# Altium schematic sheet 04_Misc.SchDoc(04_Misc)
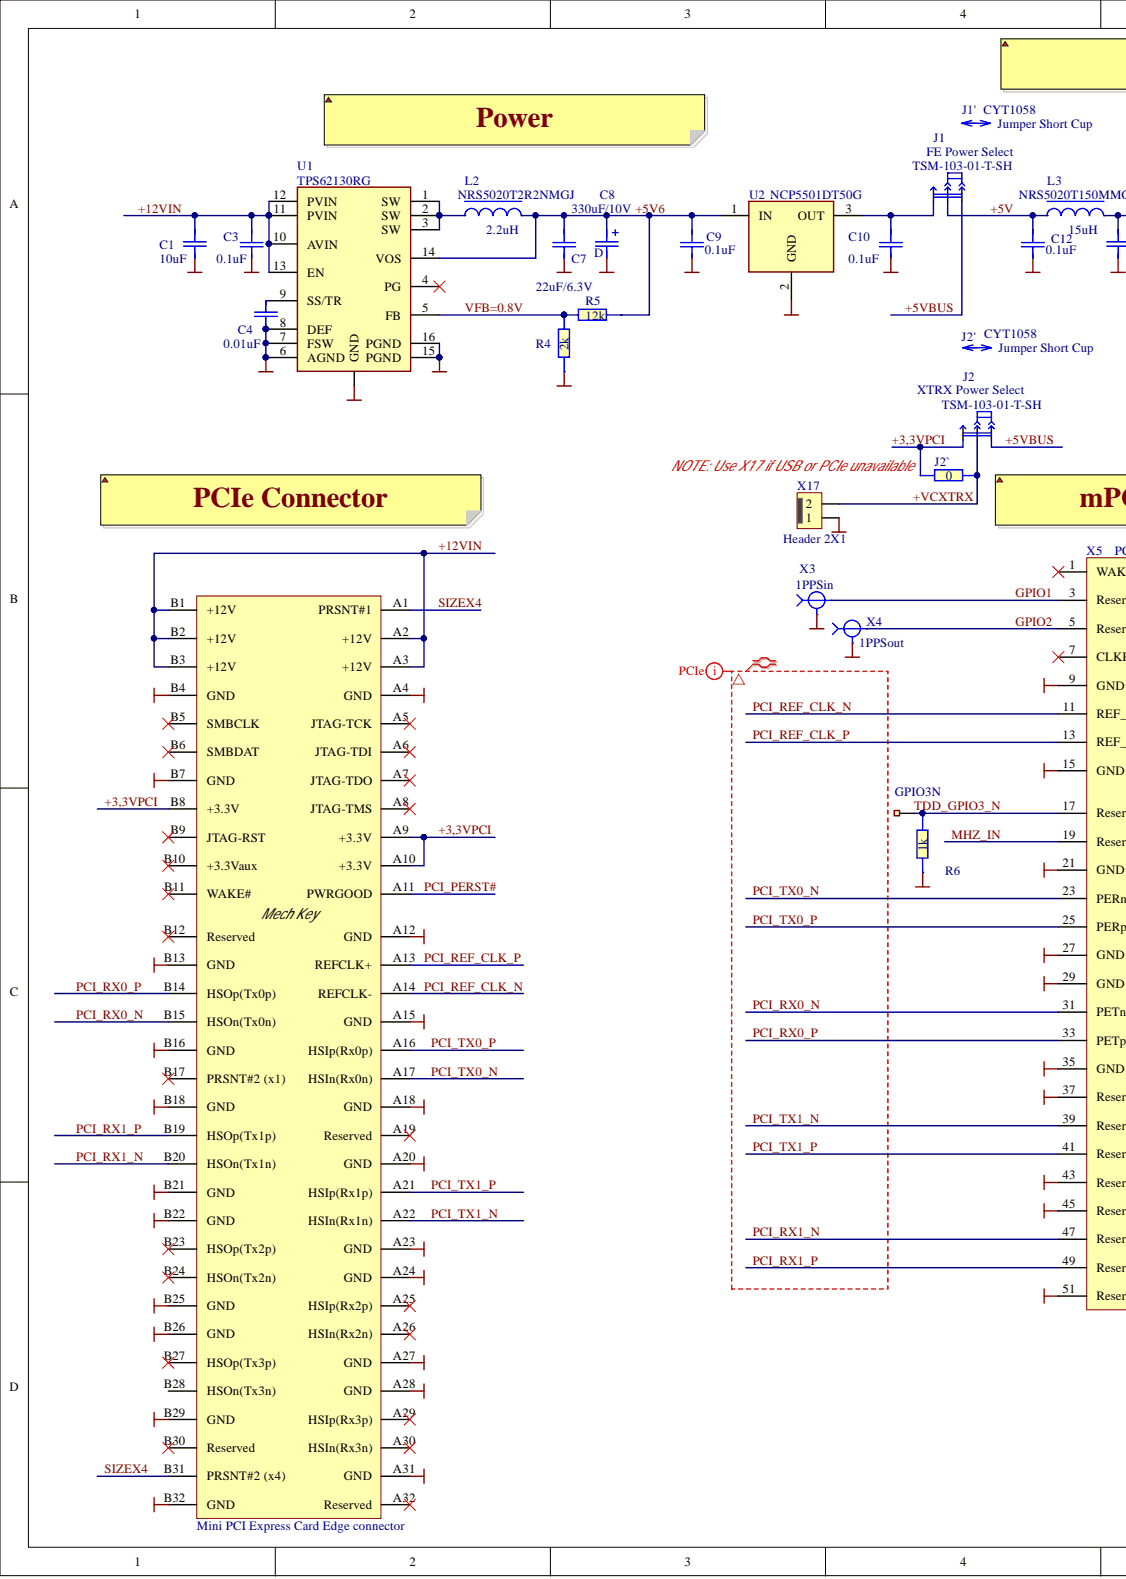
[diagram p0: 04_Misc.SchDoc, left]
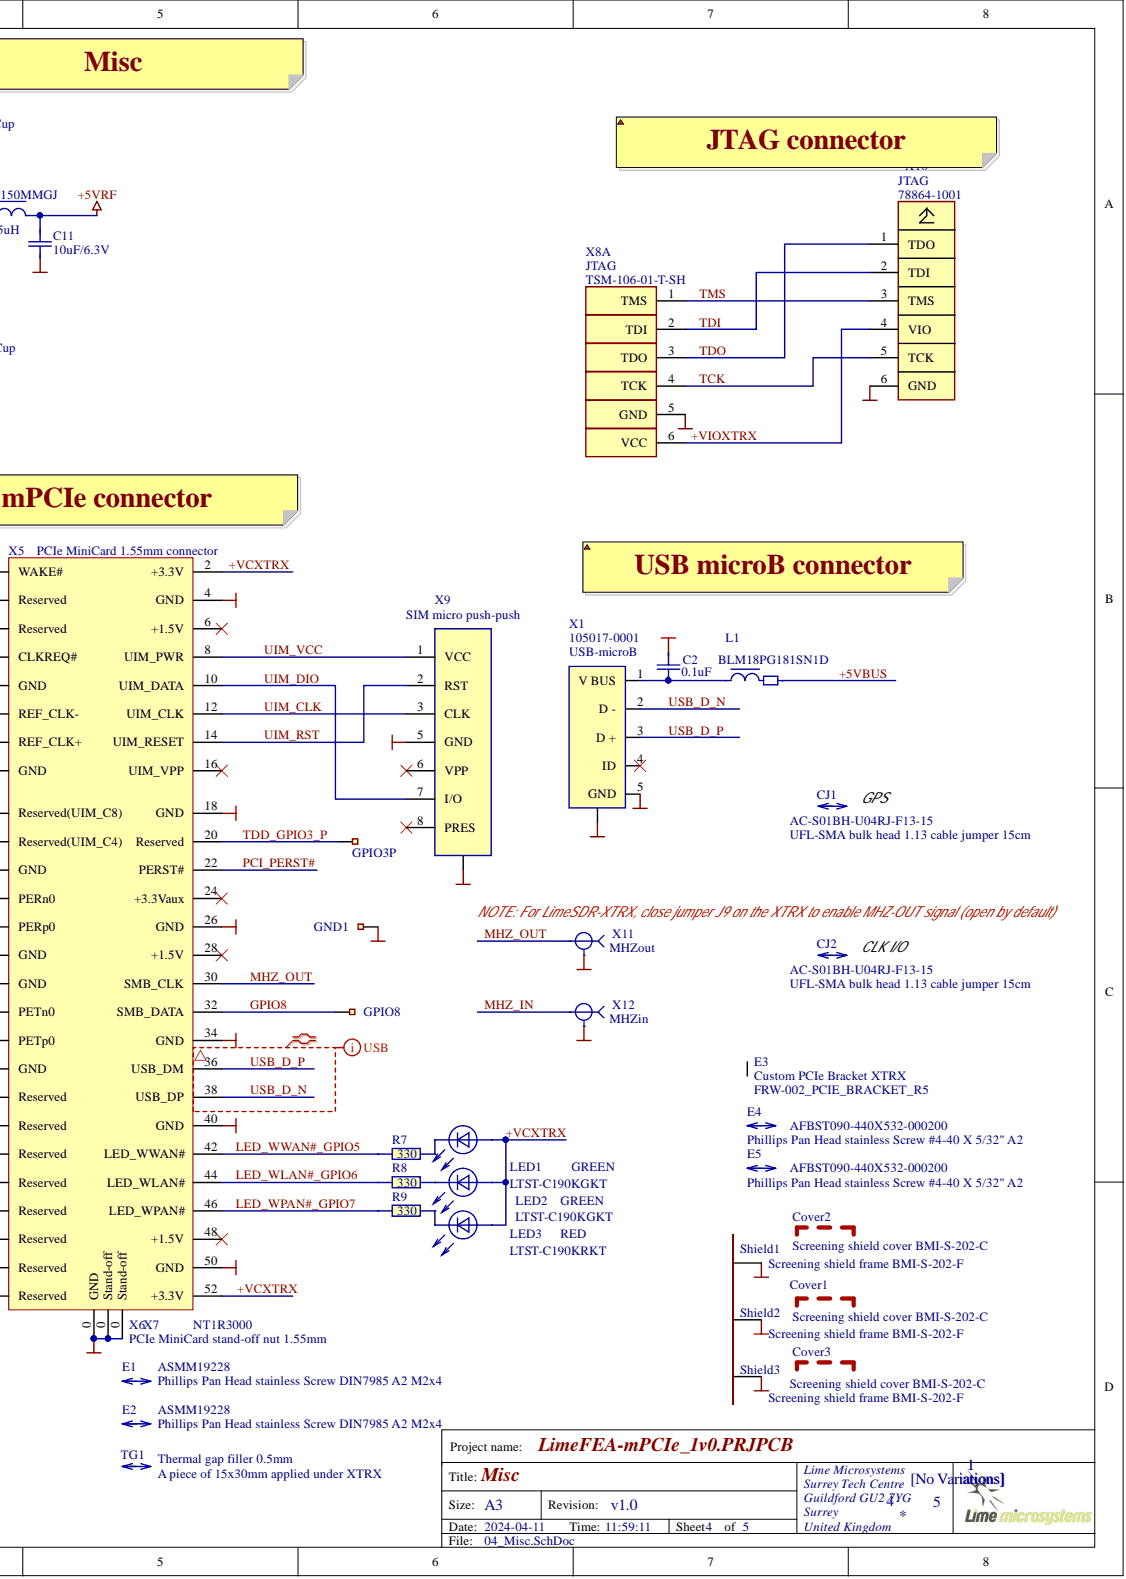
[diagram p1: 04_Misc.SchDoc, right]

## Parts
C1  10uF  [C0805]
C2  0.1uF  [C0603-PHILLIPS]
C3  0.1uF  [C0603-PHILLIPS]
C4  0.01uF  [C0603-PHILLIPS]
C7  22uF/6.3V  [C0603-PHILLIPS]
C8  330uF/10V  [cd7343]
C9  0.1uF  [C0603-PHILLIPS]
C10  0.1uF  [C0603-PHILLIPS]
C11  10uF/6.3V  [C0603-Phillips]
C12  0.1uF  [C0603-PHILLIPS]
CJ1  AC-S01BH-U04RJ-F13-15  (mechanical)
CJ2  AC-S01BH-U04RJ-F13-15  (mechanical)
Cover1  Screening shield cover BMI-S-202-C  (mechanical)
Cover2  Screening shield cover BMI-S-202-C  (mechanical)
Cover3  Screening shield cover BMI-S-202-C  (mechanical)
E1  ASMM19228  (mechanical)
E2  ASMM19228  (mechanical)
E3  Custom PCIe Bracket XTRX  value FRW-002_PCIE_BRACKET_R5  [Custom_PCIe_bracket_XTRX1]
E4  AFBST090-440X532-000200  (mechanical)
E5  AFBST090-440X532-000200  (mechanical)
GND1  (no value)  [KP-C_d1mm]  (no BOM)
GPIO3N  (no value)  [KP-C_d1mm]  (no BOM)
GPIO3P  (no value)  [KP-C_d1mm]  (no BOM)
GPIO8  (no value)  [KP-C_d1mm]  (no BOM)
J1  TSM-103-01-T-SH  value FE Power Select  [Head_Male_3P_R/A_SMD]
J1'  CYT1058  (mechanical)
J2  TSM-103-01-T-SH  value XTRX Power Select  [Head_Male_3P_R/A_SMD]
J2'  CYT1058  (mechanical)
J2`  0  [R0603-PHILLIPS]
L1  BLM18PG181SN1D  [TI160808-Phillips]
L2  2.2uH  Name: NRS5020T2R2NMGJ  [L-NRS5030]
L3  15uH  Name: NRS5020T150MMGJ  [L-NRS5030]
LED1  LTST-C190KGKT  value GREEN  [LED-0603GR]
LED2  LTST-C190KGKT  value GREEN  [LED-0603GR]
LED3  LTST-C190KRKT  value RED  [LED-0603RD]
R4  2k  [R0603-PHILLIPS]
R5  12k  [R0603-PHILLIPS]
R6  1k  [R0603-PHILLIPS]
R7  330  [R0603-PHILLIPS]
R8  330  [R0603-PHILLIPS]
R9  330  [R0603-PHILLIPS]
Shield1  Screening shield frame BMI-S-202-F  [BMI-S-202-F]
Shield2  Screening shield frame BMI-S-202-F  [BMI-S-202-F]
Shield3  Screening shield frame BMI-S-202-F  [BMI-S-202-F]
TG1  A piece of 15x30mm applied under XTRX  (mechanical)
U1  TPS62130RG  [QFN16(3x3/0/5mm)-Case485AE]
U2  NCP5501DT50G  [DPAK-3]
X1  105017-0001  Name: USB-microB  [USB-micro-B]
X2  Mini PCI Express Card Edge connector  [PCIe x4 edge connector]  (no BOM)
X3  Misc: 1PPSin  [U_FL]  (hidden comment: 0734120110)
X4  Misc: 1PPSout  [U_FL]  (hidden comment: 0734120110)
X5  PCIe MiniCard 1.55mm connector  [PCIe MiniCard 1.55mm conn]
X6  NT1R3000  [PCIe MiniCard 1.55mm stand off nut]
X7  NT1R3000  [PCIe MiniCard 1.55mm stand off nut]
X8  TSM-106-01-T-SH  value JTAG  [Head_Male_6P_R/A_SMD]  (parts A,B,C,D,E,F)
X9  SIM micro push-push  [SIM_SF56S006V4BR2000-dig]
X10  JTAG  Name: 78864-1001  [Molex 78864-1001]
X11  Misc: MHZout  [U_FL]  (hidden comment: 0734120110)
X12  Misc: MHZin  [U_FL]  (hidden comment: 0734120110)
X17  Header 2X1  [Header1x2_TH_LOCKING]

## Nets
+5VRF: C11.2 L3.2
GND: C1.2 C2.2 C3.1 C4.2 C7.1 C8.2 C9.1 C10.1 C11.1 C12.1 GND1.1 R4.2 R6.1 Shield1.0 Shield2.0 Shield3.0 U1.0(GND) U1.6(AGND) U1.7(FSW) U1.8(DEF) U1.15(PGND) U1.16(PGND) U2.2 X1.0 X1.5(GND) X2.A4(GND) X2.A12(GND) X2.A15(GND) X2.A18(GND) X2.A20(GND) X2.A23(GND) X2.A24(GND) X2.A27(GND) X2.A28(GND) X2.A31(GND) X2.B4(GND) X2.B7(GND) X2.B13(GND) X2.B16(GND) X2.B18(GND) X2.B21(GND) X2.B22(GND) X2.B25(GND) X2.B26(GND) X2.B29(GND) X2.B32(GND) X3.2 X4.2 X5.0(GND) X5.4(GND) X5.9(GND) X5.15(GND) X5.18(GND) X5.21(GND) X5.26(GND) X5.27(GND) X5.29(GND) X5.34(GND) X5.35(GND) X5.37(Reserved) X5.40(GND) X5.43(Reserved) X5.45(Reserved) X5.50(GND) X5.51(Reserved) X6.0 X7.0 X8.5(GND) X9.0 X9.5(GND) X10.6(GND) X11.2 X12.2 X17.1
NetCJ1: CJ1.
NetCJ2: CJ2.
NetE1: E1.
NetE2: E2.
NetE4: E4.
NetE5: E5.
NetJ1': J1'.
NetJ2': J2'.
NetTG1: TG1.
+3,3VPCI: J2.3 J2`.1 X2.A9(+3.3V) X2.A10(+3.3V) X2.B8(+3.3V)
+5V: C12.2 J1.2 L3.1
+5V6: C7.2 C8.1 C9.2 L2.2 R5.2 U1.14(VOS) U2.1
+5VBUS: J1.1 J2.1 L1.2
+12VIN: C1.1 C3.2 U1.10(AVIN) U1.11(PVIN) U1.12(PVIN) U1.13(EN) X2.A2(+12V) X2.A3(+12V) X2.B1(+12V) X2.B2(+12V) X2.B3(+12V)
+VCXTRX: J2.2 J2`.2 LED1.2 LED2.2 LED3.2 X5.2(+3.3V) X5.52(+3.3V) X17.2
+VIOXTRX: X8.6(VCC) X10.4(VIO)
GPIO1: X3.1 X5.3(Reserved)
GPIO2: X4.1 X5.5(Reserved)
GPIO8: GPIO8.1 X5.32(SMB_DATA)
LED_WLAN#_GPIO6: R8.1 X5.44(LED_WLAN#)
LED_WPAN#_GPIO7: R9.1 X5.46(LED_WPAN#)
LED_WWAN#_GPIO5: R7.1 X5.42(LED_WWAN#)
MHZ_IN: X5.19(Reserved(UIM_C4)) X12.1
MHZ_OUT: X5.30(SMB_CLK) X11.1
PCI_PERST#: X2.A11(PWRGOOD) X5.22(PERST#)
PCI_REF_CLK_N: X2.A14(REFCLK-) X5.11(REF_CLK-)
PCI_REF_CLK_P: X2.A13(REFCLK+) X5.13(REF_CLK+)
PCI_RX0_N: X2.B15(HSOn(Tx0n)) X5.31(PETn0)
PCI_RX0_P: X2.B14(HSOp(Tx0p)) X5.33(PETp0)
PCI_RX1_N: X2.B20(HSOn(Tx1n)) X5.47(Reserved)
PCI_RX1_P: X2.B19(HSOp(Tx1p)) X5.49(Reserved)
PCI_TX0_N: X2.A17(HSIn(Rx0n)) X5.23(PERn0)
PCI_TX0_P: X2.A16(HSIp(Rx0p)) X5.25(PERp0)
PCI_TX1_N: X2.A22(HSIn(Rx1n)) X5.39(Reserved)
PCI_TX1_P: X2.A21(HSIp(Rx1p)) X5.41(Reserved)
SIZEX4: X2.A1(PRSNT#1) X2.B31(PRSNT#2_(x4))
TCK: X8.4(TCK) X10.5(TCK)
TDD_GPIO3_N: GPIO3N.1 R6.2 X5.17(Reserved(UIM_C8))
TDD_GPIO3_P: GPIO3P.1 X5.20(Reserved)
TDI: X8.2(TDI) X10.2(TDI)
TDO: X8.3(TDO) X10.1(TDO)
TMS: X8.1(TMS) X10.3(TMS)
UIM_CLK: X5.12(UIM_CLK) X9.3(CLK)
UIM_DIO: X5.10(UIM_DATA) X9.7(I/O)
UIM_RST: X5.14(UIM_RESET) X9.2(RST)
UIM_VCC: X5.8(UIM_PWR) X9.1(VCC)
USB_D_N: X1.2(D_-) X5.38(USB_DP)
USB_D_P: X1.3(D_+) X5.36(USB_DM)
NetC2_1: C2.1 L1.1 X1.1(V_BUS)
NetC4_1: C4.1 U1.9(SS/TR)
NetC10_2: C10.2 J1.3 U2.3
NetL2_1: L2.1 U1.1(SW) U1.2(SW) U1.3(SW)
NetLED1_1: LED1.1 R7.2
NetLED2_1: LED2.1 R8.2
NetLED3_1: LED3.1 R9.2
NetR4_1: R4.1 R5.1 U1.5(FB)
N00018:
N00019:
N00020:
N00021:

Not connected: E3 0; U1 4(PG); X1 4(ID); X2 A5(JTAG-TCK) A6(JTAG-TDI) A7(JTAG-TDO) A8(JTAG-TMS) A19(Reserved) A25(HSIp(Rx2p)) A26(HSIn(Rx2n)) A29(HSIp(Rx3p)) A30(HSIn(Rx3n)) A32(Reserved) B5(SMBCLK) B6(SMBDAT) B9(JTAG-RST) B10(+3.3Vaux) B11(WAKE#) B12(Reserved) B17(PRSNT#2_(x1)) B23(HSOp(Tx2p)) B24(HSOn(Tx2n)) B27(HSOp(Tx3p)) B28(HSOn(Tx3n)) B30(Reserved); X5 1(WAKE#) 6(+1.5V) 7(CLKREQ#) 16(UIM_VPP) 24(+3.3Vaux) 28(+1.5V) 48(+1.5V); X9 6(VPP) 8(PRES)

## Library data of the parts
C1 = C0805: Capacitor; Manufacturer: MURATA; U/P/TKE: X5R/16V; Tolerance: 20%; Name: SMD0805; Value: 10uF; PCB3D: CR0805_0_0000_C; Link: https://octopart.com/search?start=0&sort=avg_price&sort-dir=asc&category_ids=6332&specs.capacitance.value=1e-05&specs.voltage_rating_dc.value=25&avg_avail=(1__*)&specs.case_package_si.value=2012; Library Name: Capacitors.SCHLIB; Component Kind: Standard
C2-C4 C9 C10 C12 = C0603: Capacitor; Manufacturer: MURATA; U/P/TKE: X7R; Tolerance: 20%; Name: SMD0603; Component Kind: Standard
  C2 C3 C9 C10 C12: Value: 0.1uF
  C4: Value: 0.01uF; Library Name: Capacitors.SCHLIB
C7 = C0603: Capacitor; Manufacturer: MURATA; U/P/TKE: X5R/6.3V; Tolerance: 20%; Name: SMD0603; Value: 22uF/6.3V; Link: https://octopart.com/grm188r60j226mea0d-murata-21407286; Component Kind: Standard
C8 = CD7343: Capacitor; Manufacturer: Kemet; U/P/TKE: 10V; Name: CASE-D; Value: 330uF/10V; Link: https://octopart.com/search?q=330uF+10V+tantalum+D; Component Kind: Standard
C11 = C0603: Capacitor; Manufacturer: MURATA; U/P/TKE: Y5V; Tolerance: 20%; Name: SMD0603; Value: 10uF/6.3V; Link: https://octopart.com/search?q=0603+10uF+6.3v; Library Name: Capacitors.SCHLIB; Component Kind: Standard
CJ1 CJ2 = Mating part: Cable jumper; Manufacturer: RFSupplier; Misc: UFL-SMA bulk head 1.13 cable jumper 15cm; Name: AC-S01BH-U04RJ-F13-15; Link: https://rfsupplier.com/sma-female-to-ipex-ufl-connector-cable-with-rg113-cbale_p6418.html; Library Name: LimeFEA-mPCIe_1v0.SchLib
Cover1-Cover3 = Screen cover BMI-S-202-C: Screening shield cover; Manufacturer: Laird Tech; Name: Screening shield cover BMI-S-202-C; Link: https://octopart.com/bmi-s-202-c-laird-19008953; Library Name: LimeFEA-mPCIe_1v0.SchLib
E1 E2 = Mating subpart 1: Screw M2; Manufacturer: Aspen Fasteners; Misc: Phillips Pan Head stainless Screw DIN7985 A2 M2x4; Name: ASMM19228; Link: https://www.amazon.com/dp/B00BNCAFR2/ref=cm_sw_r_cp_ep_dp_pCFuBbPAM8QH4; Library Name: LimeFEA-mPCIe_1v0.SchLib
E3 = PCI_Bracket_XTRX1: CNC'ed enclosure component; Name: Custom PCIe Bracket XTRX; Link: https://drive.google.com/drive/u/0/folders/1H4kDKzo1hdiNwqkA16l54XGZ7OmraAuD; Component Kind: Standard; Library Name: LimeFEA-mPCIe_1v0.SchLib
E4 E5 = Mating subpart 1: Screw #4-40; Manufacturer: Aspen Fasteners; Misc: Phillips Pan Head stainless Screw #4-40 X 5/32" A2; Name: AFBST090-440X532-000200; Link: https://www.amazon.com/dp/B019EEPRJQ/ref=cm_sw_r_cp_ep_dp_erIuBbK2SDENX; Library Name: LimeFEA-mPCIe_1v0.SchLib
GND1 GPIO3N GPIO3P GPIO8 = KP40X40: Manufacturer: PCB; Library Name: Connectors.SCHLIB
J1 = JUMPER_3P R/A SMD: Jumper 3 pin; Manufacturer: Samtec; Value: FE Power Select; Misc: SMT 3pin R/A jumper/connector; Name: TSM-103-01-T-SH; Link: https://octopart.com/tsm-103-01-t-sh-samtec-8024795?r=sp&s=QwI2rG3eQKmfzGqx_DrZCQ; Component Kind: Standard; Library Name: LimeFEA-mPCIe_1v0.SchLib
J1' J2' = Mating part: Jumper Short Cup; Name: CYT1058; Misc: Jumper Short Cup; Link: https://www.amazon.com/dp/B06X1FFLMR/ref=sspa_dk_detail_0?psc=1&pd_rd_i=B06X1FFLMR&pd_rd_wg=glUhP&pd_rd_r=7KQW9WJBAB1TXMG7AV38&pd_rd_w=YmwM8
  J1': Library Name: LimeFEA-mPCIe_1v0.SchLib
  J2': Library Name: Andy.SchLib
J2 = JUMPER_3P R/A SMD: Jumper 3 pin; Manufacturer: Samtec; Misc: SMT 3pin R/A jumper/connector; Name: TSM-103-01-T-SH; Link: https://octopart.com/tsm-103-01-t-sh-samtec-8024795?r=sp&s=QwI2rG3eQKmfzGqx_DrZCQ; Component Kind: Standard; Library Name: LimeFEA-mPCIe_1v0.SchLib
J2` = R0603: Jumper; Manufacturer: YAGEO; U/P/TKE: 0.1W; Tolerance: 1%; Name: SMD0603; Value: 0; PCB3D: 1608[0603]_0_0000_R; Component Kind: Standard
L1 = EMI_BLM18: Ferrite Bead EMIFIL; Manufacturer: MURATA; U/P/TKE: 180 Ohm, 90 mOhm,1.5A; Name: BLM18PG181SN1D; Link: https://octopart.com/blm18pg181sn1d-murata-755298?r=sp&s=UoqSqWT2SxaJq0Sn2mx1DQ; Component Kind: Standard; Library Name: LimeFEA-mPCIe_1v0.SchLib
L2 = L-NRS50x0: Coil; Manufacturer: Taiyo Yuden; U/P/TKE: 2.9A/42mOhm; Tolerance: 20%; Value: 2.2uH; Link: https://octopart.com/nrs5020t150mmgj-taiyo+yuden-13146350; Component Kind: Standard; Library Name: LimeFEA-mPCIe_1v0.SchLib
L3 = L-NRS50x0: Coil; Manufacturer: Taiyo Yuden; U/P/TKE: 1.1A/165mOhm; Tolerance: 20%; Value: 15uH; Link: https://octopart.com/nrs5020t150mmgj-taiyo+yuden-13146350; Component Kind: Standard; Library Name: LimeFEA-mPCIe_1v0.SchLib
LED1 LED2 = LED0603GR_Lite-On: LED GREEN; Manufacturer: Lite-On Inc; U/P/TKE: 40mcd, 2V/20mA, -30+85°C; Name: LTST-C190KGKT; Link: https://octopart.com/ltst-c190kgkt-lite-on-549897; Component Kind: Standard; Library Name: LimeFEA-mPCIe_1v0.SchLib
LED3 = LED0603RD_Lite-On: LED RED; Manufacturer: Lite-On Inc; U/P/TKE: 100mcd, 2V/20mA, -30+85°C; Name: LTST-C190KRKT; Link: http://www.digikey.com/product-detail/en/LTST-C190KRKT/160-1436-1-ND/386816; Component Kind: Standard; Library Name: LimeFEA-mPCIe_1v0.SchLib
R4-R9 = R0603: Resistor; Manufacturer: YAGEO; U/P/TKE: 0.1W; Tolerance: 1%; Name: SMD0603; PCB3D: 1608[0603]_0_0000_R; Component Kind: Standard
  R4: Value: 2k; Library Name: Resistors.SCHLIB
  R5: Value: 12k; Library Name: Resistors.SCHLIB
  R6: Value: 1k
  R7-R9: Value: 330
Shield1-Shield3 = Screen frame BMI-S-202-F: Screening shield frame; Manufacturer: Laird Tech; Name: Screening shield frame BMI-S-202-F; Link: https://octopart.com/bmi-s-202-f-laird-19008954; Component Kind: Standard; Library Name: LimeFEA-mPCIe_1v0.SchLib
TG1 = Mating subpart 1: Thermal gap filler; Manufacturer: Wakefield; Name: PL-05-5-1016-H; Misc: Thermal gap filler 0.5mm; Value: A piece of 15x30mm applied under XTRX; Link: https://octopart.com/pl-05-5-1016-h-wakefield-80458840; Library Name: LimeFEA-mPCIe_1v0.SchLib
U1 = TPS6213x: IC; Manufacturer: TI; U/P/TKE: 3-17V; Swap: No; PDF: http://www.ti.com/lit/ds/symlink/tps62130.pdf; Name: TPS62130RG; Link: https://octopart.com/search?q=tps62130rg; Component Kind: Standard; Library Name: LimeFEA-mPCIe_1v0.SchLib
U2 = NCP5501DTxxG: IC LDO fixed Vo; Manufacturer: ON Semi; U/P/TKE: 5.0V/0.5A/3%; Name: NCP5501DT50G; Link: https://octopart.com/ncp5501dt50g-on+semiconductor-1008548?r=sp&s=uWahieJ2SIu9_CpFmEZfrA; Component Kind: Standard; Library Name: LimeFEA-mPCIe_1v0.SchLib
X1 = USB-microB_105017-1: Connector microUSB-B; Manufacturer: MOLEX; Value: 105017-0001; Link: https://octopart.com/1050170001-molex-11866487?r=sp&s=Om3EEUYtRd-BHyhqotd9rA; Component Kind: Standard; Library Name: LimeFEA-mPCIe_1v0.SchLib
X2 = PCIe x4 Card: PCIe x4 Card edge connector; Library Name: LimeFEA-mPCIe_1v0.SchLib
X3 X4 X11 X12 = U_FL: Connector (UMCC); Manufacturer: Molex; Name: 0734120110; Link: https://octopart.com/0734120110-molex-16756629; Component Kind: Standard; Library Name: LimeFEA-mPCIe_1v0.SchLib
X5 = PCIe MiniCard conn h1.55mm: Connector PCIe MiniCard; Manufacturer: JAE Electronics; Name: MM60-52B1-G1; Link: https://octopart.com/mm60-52b1-g1-r850-jae+electronics-19499790; Component Kind: Standard; Library Name: LimeFEA-mPCIe_1v0.SchLib
X6 X7 = PCIe MiniCard nut h1.55mm: Stand-off nut PCIe MiniCard; Manufacturer: JAE Electronics; Misc: PCIe MiniCard stand-off nut 1.55mm; Name: NT1R3000; Link: https://octopart.com/nt1r3000-jae+electronics-19043816; Component Kind: Standard; Library Name: LimeFEA-mPCIe_1v0.SchLib
X8 = Conn TSM-106-01-T-SH (=M20-89605): Connector header male; Manufacturer: Samtec; Name: TSM-106-01-T-SH; Link: https://octopart.com/tsm-106-01-t-sh-samtec-8444065?r=sp&s=4nKo29egTCGXCLlTpUf1dA; Component Kind: Standard; Library Name: LimeFEA-mPCIe_1v0.SchLib
X9 = SIM micro push-push: Connector SIM micro card; Manufacturer: JAE; Value: SF56S006V4BR2000; Link: https://octopart.com/sf56s006v4br2000-jae+electronics-55772495?r=sp&s=RZW5_ItfTZ2wF5MtOkXdLQ; Component Kind: Standard; Library Name: LimeFEA-mPCIe_1v0.SchLib
X10 = Conn Molex_788641001: Connector board to board; Manufacturer: Molex; Misc: Connector board to board, 6 spring contacts; Value: JTAG; Link: https://octopart.com/78864-1001-molex-52668680?r=sp&s=CalIQCkHRmWKRIBINWJXJg; Component Kind: Standard; Library Name: LimeFEA-mPCIe_1v0.SchLib
X17 = Header 2X1 LOCK: Headers & Wire Housings KK 100 Hdr FrLk Vert 02 Ckt Gold; Part Description: Connector Header Through Hole 2 position 0.100" (2.54mm); Manufacturer 1: Molex; Supplier Part Number 1: WM19460-ND; Supplier 1: Digi-Key; Stock 1: 0; RoHS: Yes; Manufacturer Part Number 1: 22-11-2026; Manufacturer Part Number: 22-11-2026; Manufacturer: Molex; ComponentLink2URL: https://datasheet.ciiva.com/30212/022232051-sd-30212109.pdf?src-supplier=Onlinecomponents.com; ComponentLink2Description: https://datasheet.ciiva.com/30212/022232051-sd-30212109.pdf?src-supplier=Onlinecomponents.com; ComponentLink1URL: https://datasheet.ciiva.com/5156/022045042-sd-206842-5156139.pdf?src-supplier=Mouser; ComponentLink1Description: https://datasheet.ciiva.com/5156/022045042-sd-206842-5156139.pdf?src-supplier=Mouser; Component Kind: Standard; Library Name: LimeMicroAltiumLib_schLib_r04.SchLib
